# Altium schematic sheet MCU&CANbus.SchDoc
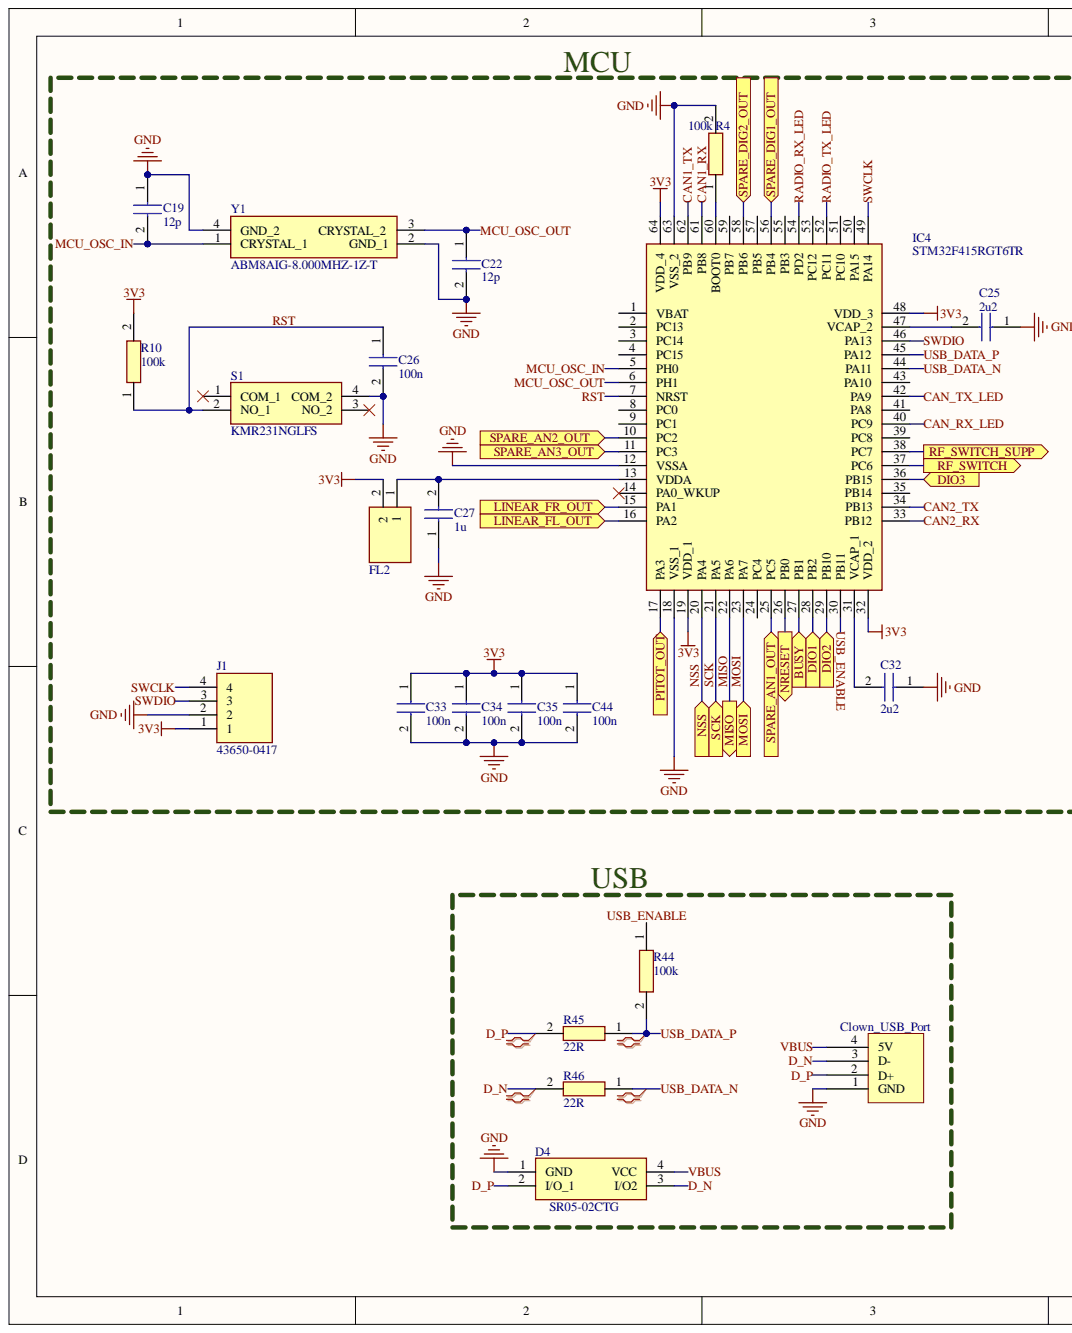
[diagram p0: MCU&CANbus.SchDoc, left]
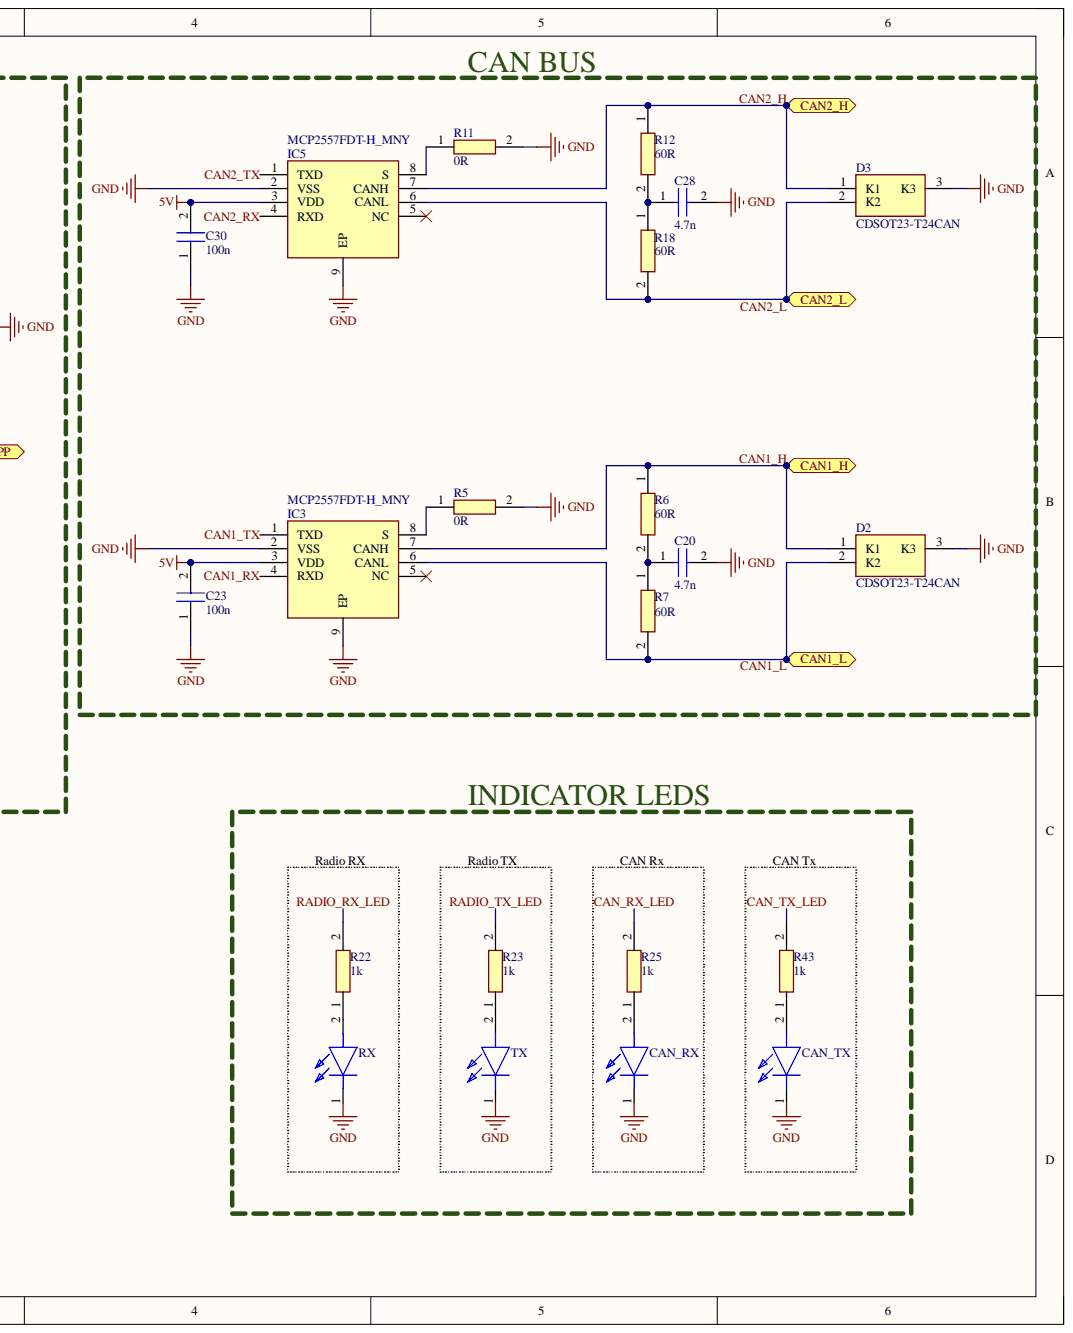
[diagram p1: MCU&CANbus.SchDoc, right]

## Parts
*  Clown_USB_Port  [CLOWN_USB_PORT]
C19  12p  [CAPC1005X55N]
C20  4.7n  [CAPC1005X55N]
C22  12p  [CAPC1005X55N]
C23  100n  [CAPC1005X55N]
C25  2u2  [CAPC1608X90N]
C26  100n  [CAPC1005X55N]
C27  1u  [CAPC1608X90N]
C28  4.7n  [CAPC1005X55N]
C30  100n  [CAPC1005X55N]
C32  2u2  [CAPC1608X90N]
C33  100n  [CAPC1005X55N]
C34  100n  [CAPC1005X55N]
C35  100n  [CAPC1005X55N]
C44  100n  [CAPC1005X55N]
CAN_RX  LTST-C170KRKT  [LEDC2012X120N]  (value not drawn)
CAN_TX  LTST-C170KRKT  [LEDC2012X120N]  (value not drawn)
D2  CDSOT23-T24CAN  [SOT95P230X117-3N]
D3  CDSOT23-T24CAN  [SOT95P230X117-3N]
D4  SR05-02CTG  [SR0502CTG]
FL2  BLM18PG181SN1D  [BLM18AG102BH1D]  (value not drawn)
IC3  MCP2557FDT-H_MNY  [SON50P300X200X80-9N-D]
IC4  STM32F415RGT6TR  [QFP50P1200X1200X160-64N]
IC5  MCP2557FDT-H_MNY  [SON50P300X200X80-9N-D]
J1  43650-0417  [43650-04YY_151617]
R4  100k  [RESC1005X35N]
R5  0R  [RESC1005X35N]
R6  60R  [RESC1005X35N]
R7  60R  [RESC1005X35N]
R10  100k  [RESC1005X35N]
R11  0R  [RESC1005X35N]
R12  60R  [RESC1005X35N]
R18  60R  [RESC1005X35N]
R22  1k  [RESC1005X35N]
R23  1k  [RESC1005X35N]
R25  1k  [RESC1005X35N]
R43  1k  [RESC1005X35N]
R44  100k  [RESC1608X55N]
R45  22R  [RESC1608X55N]
R46  22R  [RESC1608X55N]
RX  LTST-C170KRKT  [LEDC2012X120N]  (value not drawn)
S1  KMR231NGLFS  [KMR231NGLFS]
TX  LTST-C170KRKT  [LEDC2012X120N]  (value not drawn)
Y1  ABM8AIG-8.000MHZ-1Z-T  [ABM8AIG8000MHZ1ZT]

## Ports
BUSY, CAN1_H, CAN1_L, CAN2_H, CAN2_L, DIO1, DIO2, DIO3, LINEAR_FL_OUT, LINEAR_FR_OUT, MISO, MOSI, NRESET, NSS, PITOT_OUT, RF_SWITCH, RF_SWITCH_SUPP, SCK, SPARE_AN1_OUT, SPARE_AN2_OUT, SPARE_AN3_OUT, SPARE_DIG1_OUT, SPARE_DIG2_OUT

## Nets
3V3: C33.1 C34.1 C35.1 C44.1 FL2.2 IC4.19(VDD_1) IC4.32(VDD_2) IC4.48(VDD_3) IC4.64(VDD_4) J1.1 R10.2
5V: C23.2 C30.2 IC3.3(VDD) IC5.3(VDD)
GND: *.1(GND) C19.1 C20.2 C22.2 C23.1 C25.1 C26.2 C27.1 C28.2 C30.1 C32.1 C33.2 C34.2 C35.2 C44.2 CAN_RX.1 CAN_TX.1 D2.3 D3.3 D4.1(GND) IC3.2(VSS) IC3.9(EP) IC4.12(VSSA) IC4.18(VSS_1) IC4.63(VSS_2) IC5.2(VSS) IC5.9(EP) J1.2 R4.2 R5.2 R11.2 RX.1 S1.4(COM_2) TX.1 Y1.2(GND_1) Y1.4(GND_2)
BUSY: IC4.27(PB1) ; port BUSY
CAN1_H: D2.1 IC3.7(CANH) R6.1 ; port CAN1_H
CAN1_L: D2.2 IC3.6(CANL) R7.2 ; port CAN1_L
CAN1_RX: IC3.4(RXD) IC4.61(PB8)
CAN1_TX: IC3.1(TXD) IC4.62(PB9)
CAN2_H: D3.1 IC5.7(CANH) R12.1 ; port CAN2_H
CAN2_L: D3.2 IC5.6(CANL) R18.2 ; port CAN2_L
CAN2_RX: IC4.33(PB12) IC5.4(RXD)
CAN2_TX: IC4.34(PB13) IC5.1(TXD)
CAN_RX_LED: IC4.40(PC9) R25.2
CAN_TX_LED: IC4.42(PA9) R43.2
D_N: *.3(D-) D4.3(I/O2) R46.2
D_P: *.2(D+) D4.2(I/O_1) R45.2
DIO1: IC4.28(PB2) ; port DIO1
DIO2: IC4.29(PB10) ; port DIO2
DIO3: IC4.36(PB15) ; port DIO3
LINEAR_FL_OUT: IC4.16(PA2) ; port LINEAR_FL_OUT
LINEAR_FR_OUT: IC4.15(PA1) ; port LINEAR_FR_OUT
MCU_OSC_IN: C19.2 IC4.5(PH0) Y1.1(CRYSTAL_1)
MCU_OSC_OUT: C22.1 IC4.6(PH1) Y1.3(CRYSTAL_2)
MISO: IC4.22(PA6) ; port MISO
MOSI: IC4.23(PA7) ; port MOSI
NRESET: IC4.26(PB0) ; port NRESET
NSS: IC4.20(PA4) ; port NSS
PITOT_OUT: IC4.17(PA3) ; port PITOT_OUT
RADIO_RX_LED: IC4.54(PD2) R22.2
RADIO_TX_LED: IC4.52(PC11) R23.2
RF_SWITCH: IC4.37(PC6) ; port RF_SWITCH
RF_SWITCH_SUPP: IC4.38(PC7) ; port RF_SWITCH_SUPP
RST: C26.1 IC4.7(NRST) R10.1 S1.2(NO_1)
SCK: IC4.21(PA5) ; port SCK
SPARE_AN1_OUT: IC4.25(PC5) ; port SPARE_AN1_OUT
SPARE_AN2_OUT: IC4.10(PC2) ; port SPARE_AN2_OUT
SPARE_AN3_OUT: IC4.11(PC3) ; port SPARE_AN3_OUT
SPARE_DIG1_OUT: IC4.56(PB4) ; port SPARE_DIG1_OUT
SPARE_DIG2_OUT: IC4.58(PB6) ; port SPARE_DIG2_OUT
SWCLK: IC4.49(PA14) J1.4
SWDIO: IC4.46(PA13) J1.3
USB_DATA_N: IC4.44(PA11) R46.1
USB_DATA_P: IC4.45(PA12) R44.2 R45.1
USB_ENABLE: IC4.30(PB11) R44.1
VBUS: *.4(5V) D4.4(VCC)
NetC20_1: C20.1 R6.2 R7.1
NetC25_2: C25.2 IC4.47(VCAP_2)
NetC27_2: C27.2 FL2.1 IC4.13(VDDA)
NetC28_1: C28.1 R12.2 R18.1
NetC32_2: C32.2 IC4.31(VCAP_1)
NetCAN_RX_2: CAN_RX.2 R25.1
NetCAN_TX_2: CAN_TX.2 R43.1
NetIC3_8: IC3.8(S) R5.1
NetIC4_60: IC4.60(BOOT0) R4.1
NetIC5_8: IC5.8(S) R11.1
NetR22_1: R22.1 RX.2
NetR23_1: R23.1 TX.2

Not connected: IC3 5(NC); IC4 1(VBAT) 2(PC13) 3(PC14) 4(PC15) 8(PC0) 9(PC1) 14(PA0_WKUP) 24(PC4) 35(PB14) 39(PC8) 41(PA8) 43(PA10) 50(PA15) 51(PC10) 53(PC12) 55(PB3) 57(PB5) 59(PB7); IC5 5(NC); S1 1(COM_1) 3(NO_2)

## Library data of the parts
C19 C20 C22 C23 C26 C28 C30 C33-C35 C44 = 06035C101K4T2A: 0402 Capacitor; Manufacturer_Name: AVX; Component Kind: Standard; Datasheet Link: https://componentsearchengine.com/Datasheets/1/06031A100FAT2A.pdf; Height: 0.9mm; Library Name: Schlib1.SchLib; Manufacturer_Part_Number: 06035C101K4T2A; Mouser Part Number: 581-06035C101K4T2A; Mouser Price/Stock: https://www.mouser.co.uk/ProductDetail/AVX/06035C101K4T2A/?qs=%252Bwax1qqoKK9kpvNfpp2NFA%3D%3D
C25 C27 C32 = 06035C101K4T2A: 0603 Capacitor; Manufacturer_Name: AVX; Component Kind: Standard; Datasheet Link: https://componentsearchengine.com/Datasheets/1/06031A100FAT2A.pdf; Height: 0.9mm; Library Name: Schlib1.SchLib; Manufacturer_Part_Number: 06035C101K4T2A; Mouser Part Number: 581-06035C101K4T2A; Mouser Price/Stock: https://www.mouser.co.uk/ProductDetail/AVX/06035C101K4T2A/?qs=%252Bwax1qqoKK9kpvNfpp2NFA%3D%3D
CAN_RX CAN_TX RX TX = LTST-C170KRKT: LED; Manufacturer_Name: Lite-On; Arrow Part Number: LTST-C170KRKT; Arrow Price/Stock: https://www.arrow.com/en/products/ltst-c170krkt/lite-on-technology?region=nac; Component Kind: Standard; Datasheet Link: https://componentsearchengine.com/Datasheets/1/LTST-C170KRKT.pdf; Height: 1.2mm; Manufacturer_Part_Number: LTST-C170KRKT; Mouser Part Number: 859-LTST-C170KRKT; Mouser Price/Stock: https://www.mouser.co.uk/ProductDetail/Lite-On/LTST-C170KRKT?qs=NUb82WqeCyrVOID%2Fxt4rgA%3D%3D
D2 D3 = CDSOT23-T24CAN: TVS Diode (Bi-directional); Manufacturer_Name: Bourns; Arrow Part Number: CDSOT23-T24CAN; Arrow Price/Stock: https://www.arrow.com/en/products/cdsot23-t24can/bourns?region=nac; Component Kind: Standard; Datasheet Link: https://www.bourns.com/docs/Product-Datasheets/CDSOT23-T24CAN.pdf; Height: 1.17mm; Manufacturer_Part_Number: CDSOT23-T24CAN; Mouser Part Number: 652-CDSOT23-T24CAN; Mouser Price/Stock: https://www.mouser.co.uk/ProductDetail/Bourns/CDSOT23-T24CAN?qs=Z7P4xsdcg2LuAOb%2FzQ7xoQ%3D%3D
D4 = SR05-02CTG: Diode; Manufacturer_Name: LITTELFUSE; Arrow Part Number: SR05-02CTG; Arrow Price/Stock: https://www.arrow.com/en/products/sr05-02ctg/littelfuse?region=nac; Component Kind: Standard; Datasheet Link: https://www.arrow.com/en/products/sr05-02ctg/littelfuse; Height: 1mm; Manufacturer_Part_Number: SR05-02CTG; Mouser Part Number: 576-SR05-02CTG; Mouser Price/Stock: https://www.mouser.co.uk/ProductDetail/Littelfuse/SR05-02CTG?qs=txCqAXsCGkfW1ErBg03wlg%3D%3D
FL2 = BLM18PG181SN1D: Filter; Manufacturer_Name: Murata Electronics; Arrow Part Number: BLM18PG181SN1D; Arrow Price/Stock: https://www.arrow.com/en/products/blm18pg181sn1d/murata-manufacturing?region=nac; Component Kind: Standard; Datasheet Link: https://www.murata.com/en-us/products/productdetail?partno=BLM18PG181SN1%23; Height: 0.95mm; Manufacturer_Part_Number: BLM18PG181SN1D; Mouser Part Number: 81-BLM18PG181SN1D; Mouser Price/Stock: https://www.mouser.co.uk/ProductDetail/Murata-Electronics/BLM18PG181SN1D?qs=sTaz8HHMol3W3rq0NJIneg%3D%3D
IC3 IC5 = MCP2557FDT-H_MNY: Integrated Circuit; Manufacturer_Name: Microchip; Manufacturer_Part_Number: MCP2557FDT-H/MNY; Arrow Part Number: MCP2557FDT-H/MNY; Arrow Price/Stock: https://www.arrow.com/en/products/mcp2557fdt-hmny/microchip-technology; Component Kind: Standard; Datasheet Link: https://ww1.microchip.com/downloads/en/DeviceDoc/20005533A.pdf; Height: 0.8mm; Mouser Part Number: 579-MCP2557FDT-H/MNY; Mouser Price/Stock: https://www.mouser.co.uk/ProductDetail/Microchip-Technology-Atmel/MCP2557FDT-H-MNY?qs=ZEMkBHnybRFF6gNsp0dI%2FA%3D%3D
IC4 = STM32F415RGT6TR: Integrated Circuit; Manufacturer_Name: STMicroelectronics; Arrow Part Number: STM32F415RGT6TR; Arrow Price/Stock: https://www.arrow.com/en/products/stm32f415rgt6tr/stmicroelectronics?region=nac; Component Kind: Standard; Datasheet Link: https://www.arrow.com/en/products/stm32f415rgt6tr/stmicroelectronics; Height: 1.6mm; Manufacturer_Part_Number: STM32F415RGT6TR; Mouser Part Number: 511-STM32F415RGT6TR; Mouser Price/Stock: https://www.mouser.co.uk/ProductDetail/STMicroelectronics/STM32F415RGT6TR?qs=qzCNEk%252BRr%252BYkYZWmJEPihQ%3D%3D
J1 = 43650-0417: Serial Wire Connector; Manufacturer_Name: Molex; Component Kind: Standard; Datasheet Link: https://www.molex.com/pdm_docs/sd/436500215_sd.pdf; Height: 9.9mm; Manufacturer_Part_Number: 43650-0417; Mouser Part Number: 538-43650-0417; Mouser Price/Stock: https://www.mouser.co.uk/ProductDetail/Molex/43650-0417?qs=HSGlLeu24BPtyyP0ZyQMkg%3D%3D
R4-R7 R10-R12 R18 R22 R23 R25 R43-R46 = CR0603-J_-1R0ELF: 0402 Resistor; Manufacturer_Name: Bourns; Manufacturer_Part_Number: CR0603-J/-1R0ELF; Component Kind: Standard; Datasheet Link: https://www.bourns.com/pdfs/chpreztr.pdf; Height: 0.55mm; Mouser Part Number: 652-CR0603-J/-1R0ELF; Mouser Price/Stock: https://www.mouser.com/Search/Refine.aspx?Keyword=652-CR0603-J%2F-1R0ELF
S1 = KMR231NGLFS: Switch; Manufacturer_Name: C & K COMPONENTS; Arrow Part Number: KMR231NGLFS; Arrow Price/Stock: https://www.arrow.com/en/products/kmr231nglfs/ck; Component Kind: Standard; Datasheet Link: https://www.ckswitches.com/media/1479/kmr2.pdf; Height: 1.9mm; Manufacturer_Part_Number: KMR231NGLFS; Mouser Part Number: 611-KMR231NGLFS; Mouser Price/Stock: https://www.mouser.co.uk/ProductDetail/CK/KMR231NGLFS?qs=sb%252But3Lm4x1Y5NsXXdZx2g%3D%3D
Y1 = ABM8AIG-8.000MHZ-1Z-T: Crystal or Oscillator; Manufacturer_Name: ABRACON; Arrow Part Number: ABM8AIG-8.000MHZ-1Z-T; Arrow Price/Stock: https://www.arrow.com/en/products/abm8aig-8.000mhz-1z-t/abracon?region=nac; Component Kind: Standard; Datasheet Link: https://abracon.com/AIGcrystals/ABM8AIG.pdf; Height: 0.8mm; Manufacturer_Part_Number: ABM8AIG-8.000MHZ-1Z-T; Mouser Part Number: 815-M8AIG-8-1ZT; Mouser Price/Stock: https://www.mouser.co.uk/ProductDetail/ABRACON/ABM8AIG-8.000MHZ-1Z-T?qs=uwxL4vQweFM9%2FeRu4wQgCQ%3D%3D
* = Clown_USB_Port: Component Kind: Standard; Library Name: Telemetry_CustomLib.SchLib
